# Altium schematic sheet CR00101.SchDoc(CR00101)
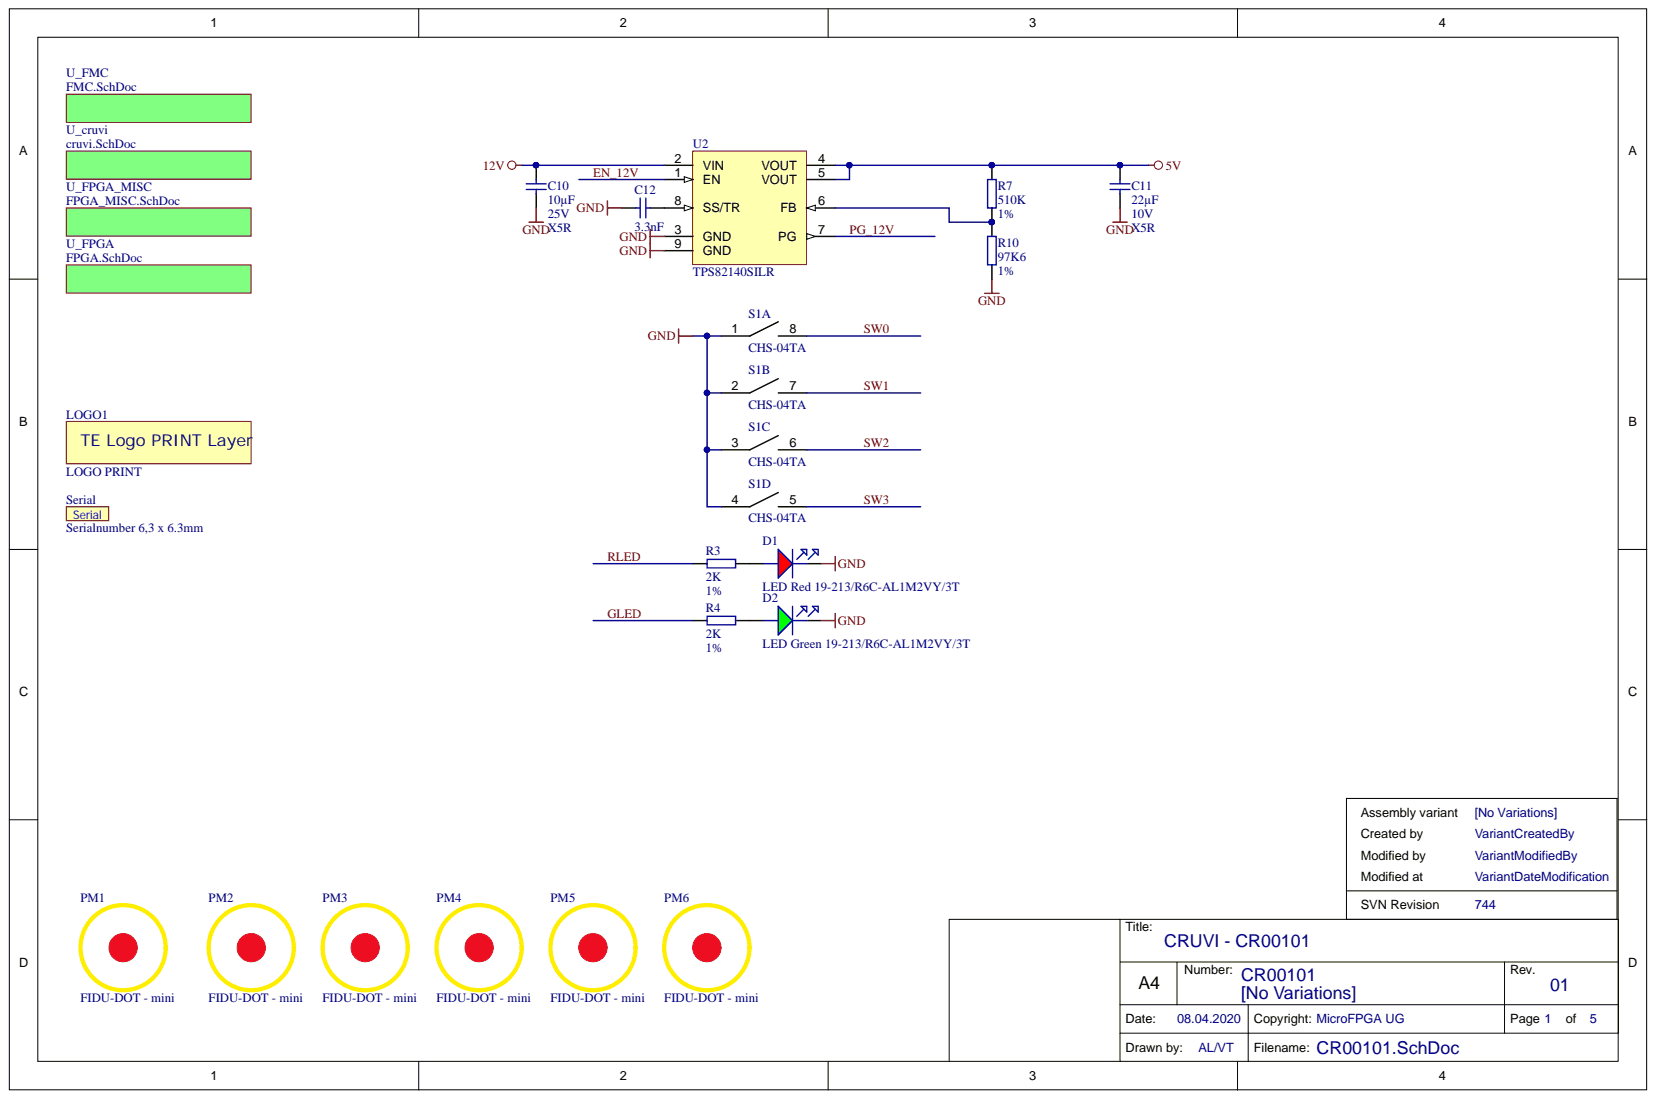
[diagram p0: CR00101.SchDoc]

## Parts
C10  10µF  Technology: X5R  Voltage: 25V  [CAPC2012X135N]
C11  22µF  Technology: X5R  Voltage: 10V  [CAPC2012X135N]
C12  3.3nF  [CAPC1005X55N]
D1  LED Red 19-213/R6C-AL1M2VY/3T  Database Table Name: LED  H-ART: 19-213/R6C-AL1M2VY/3T  [LEDC1608X55N_RED]
D2  LED Green 19-213/R6C-AL1M2VY/3T  Database Table Name: LED  [LEDC1608X55N_GREEN]
LOGO1  LOGO PRINT  [LOGO PRINT]  (no BOM)
PM1  FIDU-DOT - mini  [FIDU-DOT - mini]
PM2  FIDU-DOT - mini  [FIDU-DOT - mini]
PM3  FIDU-DOT - mini  [FIDU-DOT - mini]
PM4  FIDU-DOT - mini  [FIDU-DOT - mini]
PM5  FIDU-DOT - mini  [FIDU-DOT - mini]
PM6  FIDU-DOT - mini  [FIDU-DOT - mini]
R3  2K  [RESC1005X40N]
R4  2K  Tolerance: 1%  [RESC1005X40N]
R7  510K  Tolerance: 1%  [RESC1005X40N]
R10  97K6  Tolerance: 1%  [RESC1005X40N]
S1  CHS-04TA  [CHS-04TA]  (parts A,B,C,D)
Serial  Serialnumber 6,3 x 6.3mm  [Serial_6.3x6.3mm]  (no BOM)
U2  TPS82140SILR  [TPS82140]

## Nets
5V: C11.1 R7.1 U2.4(VOUT) U2.5(VOUT)
12V: C10.1 U2.2(VIN)
GND: C10.2 C11.2 C12.1 D1.K D2.K R10.2 S1.1 S1.2 S1.3 S1.4 U2.3(GND) U2.9(GND)
EN_12V: U2.1(EN)
GLED: R4.1
PG_12V: U2.7(PG)
RLED: R3.2
SW0: S1.8
SW1: S1.7
SW2: S1.6
SW3: S1.5
NetC12_2: C12.2 U2.8(SS/TR)
NetD1_A: D1.A R3.1
NetD2_A: D2.A R4.2
NetR7_2: R7.2 R10.1 U2.6(FB)

## Library data of the parts
C10 = 25567: KON 10µF 25V X5R 10% SMD BF 2012 (0805); Database Table Name: C_2012_0805; Mixed: SMT; Temperature-Range: -55/+85; Value: 10u; AN: 25567; Case: 2012 (0805); Component Kind: Standard; Library Name: TE.DbLib; Tolerance: 10%
C11 = 26461: KON 22µF 10V  X5R 20% SMD BF 2012 (0805); Database Table Name: C_2012_0805; Mixed: SMT; Temperature-Range: -55/+85; Value: 22u; AN: 26461; Case: 2012 (0805); Component Kind: Standard; Library Name: TE.DbLib; Tolerance: 20%
C12 = 27464: KON 3.3nF 50V X7R SMD BF 1005 (0402); Database Table Name: C_1005_0402; Mixed: SMT; Preferred: Yes; Temperature-Range: -55/+125; Value: 3.3n; AN: 27464; Case: 1005 (0402); Component Kind: Standard; Library Name: TE.DbLib; Technology: X7R; Voltage: 50V
D1 = 28261: Chip LED 0603 Briliant Red; Mixed: SMT; AN: 28261; Case: 1608 (0603); Component Kind: Standard; Library Name: TE.DbLib; HelpURL: b:\AD\adlib\datasheet\19-213-R6C\
D2 = 28262: Chip LED 0603 Briliant Green; H-ART: 19-213/G6C-BM1N2/DT; Mixed: SMT; Temperature-Range: -40/+85 (Abs); AN: 28262; Case: 1608 (0603); Component Kind: Standard; Library Name: TE.DbLib; HelpURL: b:\AD\adlib\datasheet\19-213-G6C-BM1\
LOGO1 = 23748: Database Table Name: PCB; AN: 23748; Description: LOGO PRINT; Library Name: TE.DbLib
PM1-PM6 = 27037: FIDU-DOT - MINI 0.5mm; Database Table Name: PCB; Mixed: FIDU; AN: 27037; Component Kind: Standard; Library Name: TE.DbLib
R3 R4 = 25073: WID SMD 2K 1% 0,063W  BF 1005 (0402); Database Table Name: R_1005_0402; H-ART: RC0402FR-072KL; Mixed: SMT; Preferred: Yes; AN: 25073; Case: 1005 (0402); Component Kind: Standard; Library Name: TE.DbLib; Technology: TK100; Value: 2K
  R3: Tolerance: 1%
R7 = 22935: WID SMD 510K 1% 0,063W; Database Table Name: R_1005_0402; Mixed: SMT; AN: 22935; Case: 1005 (0402); Component Kind: Standard; Library Name: TE.DbLib; Technology: TK100; Value: 510K
R10 = 25315: WID SMD 97K6 1% 0,063W; Database Table Name: R_1005_0402; Mixed: SMT; AN: 25315; Case: 1005 (0402); Component Kind: Standard; Library Name: TE.DbLib; Technology: TK100; Value: 97K6
S1 = 23417: DIP Schalter 4 fach 1,27mm SMD; Database Table Name: PB_SW; Mixed: SMT; Preferred: Yes; AN: 23417; Component Kind: Standard; H-ART: CHS-04TA; Library Name: TE.DbLib; Trenz-Stock: Yes; HelpURL: B:\AD\adlib\datasheet\CHS
Serial = 26753: Database Table Name: PCB; H-ART: 39068; Mixed: MECH; AN: 26753; Description: Serialnumber 6,3 x 6.3mm; Library Name: TE.DbLib
U2 = 29413: TPS82140SIL; Database Table Name: DCDC; Mixed: SMT; Temperature-Range: -40/+110 (Tj); AN: 29413; Case: µSIL8; Component Kind: Standard; H-ART: TPS82140SILR; Library Name: TE.DbLib; HelpURL: b:\AD\adlib\datasheet\TPS82140\
